# Altium schematic sheet Main.SchDoc(Main)
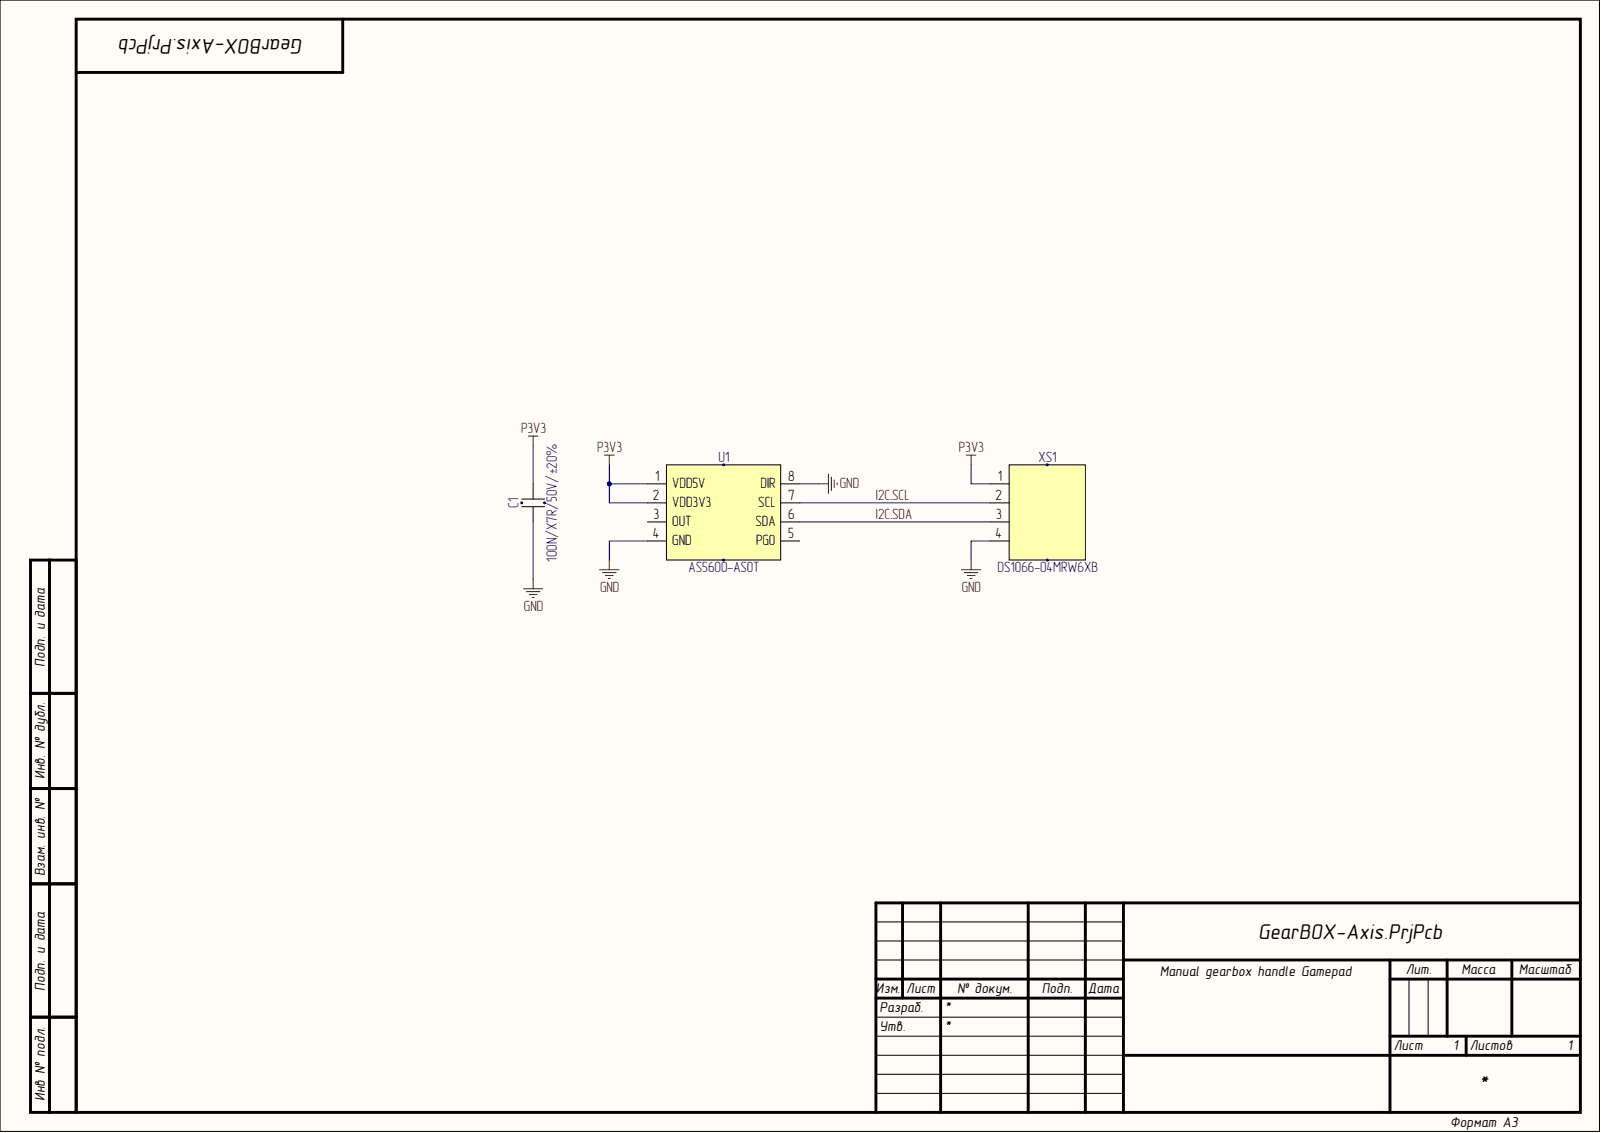
[diagram p0: Main.SchDoc]

## Parts
C1  value 100N/X7R/50V/±20%  [Normal_Capacitor_Standard_0805-L2000-W1250-BW500-H1350]  (hidden comment: CL21B104MBFNNNE)
U1  AS5600-ASOT  [8-SOIC]
XS1  DS1066-04MRW6XB  [DS1066-04MRW6XB]

## Nets
GND: C1.1 U1.4(GND) U1.8(DIR) XS1.4
P3V3: C1.2 U1.1(VDD5V) U1.2(VDD3V3) XS1.1
I2C.SCL: U1.7(SCL) XS1.2
I2C.SDA: U1.6(SDA) XS1.3

Not connected: U1 3(OUT) 5(PGO)

## Library data of the parts
C1 = CL21B104MBFNNNE: Ceramic Capacitor SMD 0805; Manufacturer: SAMSUNG ELECTRO-MECHANICS; Component Kind: Standard; Library Name: Samsung-MLCC-0805.SchLib
XS1 = DS1066-04MRW6XB: Manufacturer: CONNFLY ELECTRONIC CO.,LTD.; Component Kind: Standard; Library Name: mfmc_components.SchLib
U1 = AS5600-ASOT: Component Kind: Standard; Library Name: mfmc_components.SchLib
